# Altium schematic sheet SCH_Master.SchDoc
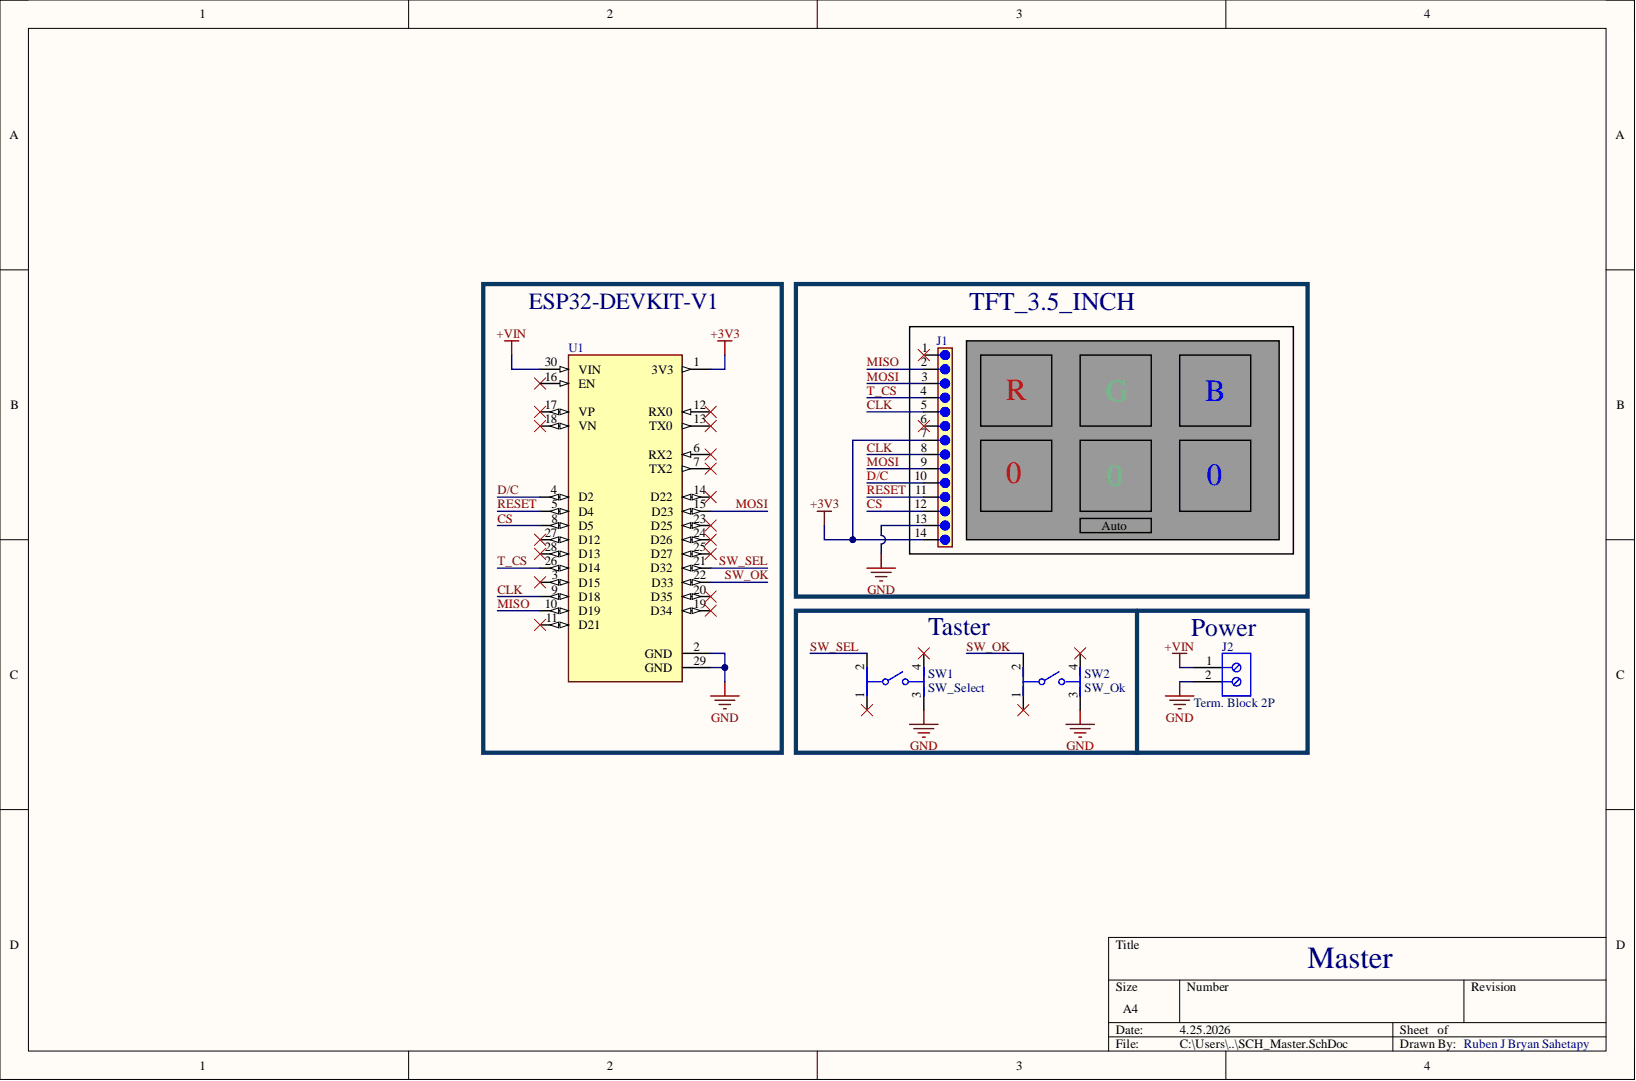
[diagram p0: SCH_Master.SchDoc]

## Parts
J1  TFT_3.5_INCH  [61301411121]
J2  Term. Block 2P  [691253410002]
SW1  SW_Select  [FP-MJTP1212A-MFG]
SW2  SW_Ok  [FP-MJTP1212A-MFG]
U1  ESP32-DEVKIT-V1  [MODULE_ESP32_DEVKIT_V1]

## Nets
+3V3: J1.7 J1.14 U1.1(3V3)
+VIN: J2.1 U1.30(VIN)
GND: J1.13 J2.2 SW1.3 SW2.3 U1.2(GND) U1.29(GND)
CLK: J1.5 J1.8 U1.9(D18)
CS: J1.12 U1.8(D5)
D/C: J1.10 U1.4(D2)
MISO: J1.2 U1.10(D19)
MOSI: J1.3 J1.9 U1.15(D23)
RESET: J1.11 U1.5(D4)
SW_OK: SW2.2 U1.22(D33)
SW_SEL: SW1.2 U1.21(D32)
T_CS: J1.4 U1.26(D14)

Not connected: J1 1 6; SW1 1 4; SW2 1 4; U1 3(D15) 6(RX2) 7(TX2) 11(D21) 12(RX0) 13(TX0) 14(D22) 16(EN) 17(VP) 18(VN) 19(D34) 20(D35) 23(D25) 24(D26) 25(D27) 27(D12) 28(D13)

## Library data of the parts
J1 = TFT-ILI9488: 3.5-inch TFT LCD (320 × 480) with ILI9488 driver + XPT2046 resistive touch; Database Table Name: Components$; MF: WÃ¼rth Elektronik; Check_prices: https://www.snapeda.com/parts/61301411121/W%25C3%25BCrth+Elektronik+Midcom/view-part/?ref=eda; Component Kind: Standard; Link: https://grabcad.com/library/3-5-color-spi-display-based-on-ili9488-chip-1; MP: 61301411121; Package: None; Part Number: TFT-ILI9488; PartID: TFT-ILI9488; SnapEDA_Link: https://www.snapeda.com/parts/61301411121/W%25C3%25BCrth+Elektronik+Midcom/view-part/?ref=snap; TempMax: 85; TempMin: -30; Value: 3.5-inch TFT LCD (320 × 480); Library Name: MarbleLib.DbLib
J2 = CMP-1502-02746-3: Terminal Block, 7.62MM Pitch, Horizontal, Rising Cage Clamp, 12-30 AWG, 2 Pin; Component Kind: Standard; Library Name: Altium Content Vault
SW1 SW2 = MJTP1212A: Switch Tactile Button 12x12mm; Actuator Length: 3.5mm; Actuator Material: Polyoxymethylene; Actuator Type: Standard; Contact Resistance: 100mR; Current Rating: 50mA; Database Table Name: Components$; Electrical Life: 100000Cycles; Illumination Type: Non-Illuminated; Max Voltage Rating (DC): 12V; Operating Force: 160gf; Switch Function: Off-Mom; Switch Travel: 0.3mm; Throw Configuration: SPST; Value: 0.05A @ 12VDC; Component Kind: Standard; Contact Material: Bronze; Contact Plating: Silver; Link: https://www.digikey.de/de/products/detail/omron-electronics-inc-emc-div/B3F-4055/31799?gclsrc=aw.ds&gad_source=1&gad_campaignid=19971370784&gbraid=0AAAAADrbLlgb8g5iB56Pq7GBfmlW8M2um&gclid=CjwKCAiA2svIBhB-EiwARWDPjsxJrtH3aqZi4wzUZvXY0ugmwA-CuPqPru_7AumdmvXcQLZq2TM71hoCQyEQAvD_BwE; Max Operating Temperature: 70°C; Mechanical Life: 100000Cycles; Min Operating Temperature: -20°C; Mount: Through Hole; Orientation: Straight; Package Quantity: 100; Packaging: Bulk; Part Number: MJTP1212A; PartID: MJTP1212A; REACH SVHC: No SVHC; TempMax: 70; TempMin: -25; Termination: PC Pin; Voltage Rating (DC): 12V; Library Name: MarbleLib.DbLib
U1 = ESP32-DEVKIT-V1: ESP32-DEVKIT-V1 30 Pins; Database Table Name: Components$; MF: Do it; Value: Dual Core, Wi-Fi, BLE; Check_prices: https://www.snapeda.com/parts/ESP32-DEVKIT-V1/Do+it/view-part/?ref=eda; Component Kind: Standard; Link: https://www.snapeda.com/parts/ESP32-DEVKIT-V1/Do+it/view-part/?ref=eda; MP: ESP32-DEVKIT-V1; Package: None; Part Number: ESP32-DEVKIT-V1; PartID: ESP32-DEVKIT-V1; SnapEDA_Link: https://www.snapeda.com/parts/ESP32-DEVKIT-V1/Do+it/view-part/?ref=snap; TempMax: 85; TempMin: -40; Library Name: MarbleLib.DbLib
